# Altium schematic sheet OBC.SchDoc(OBC)
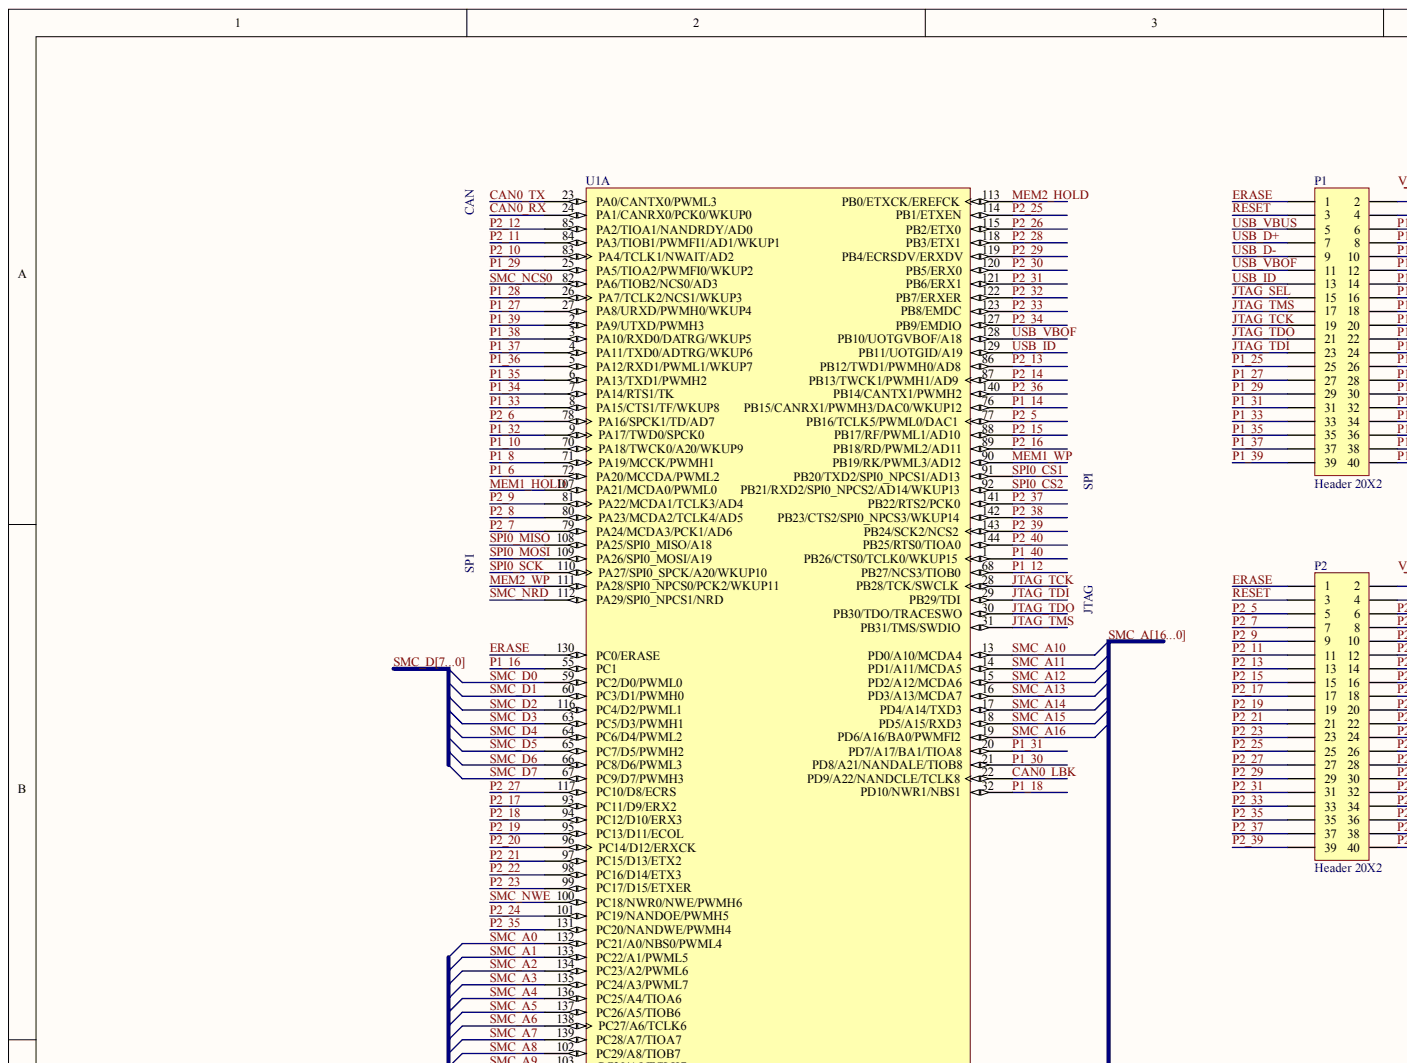
[diagram p0: OBC.SchDoc, top left]
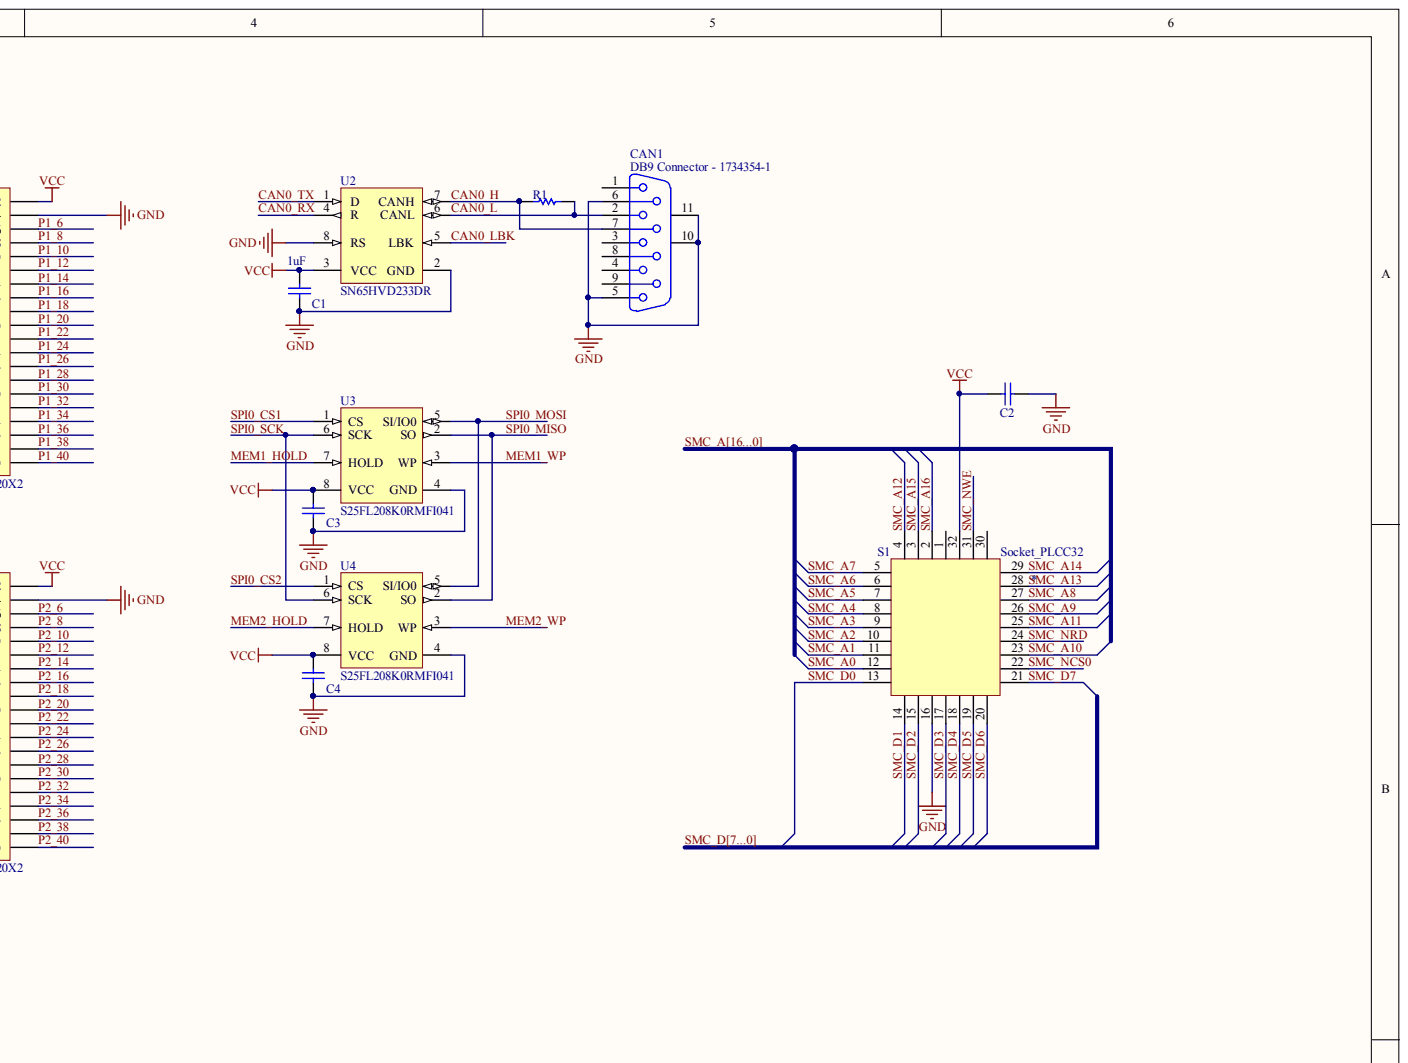
[diagram p1: OBC.SchDoc, top right]
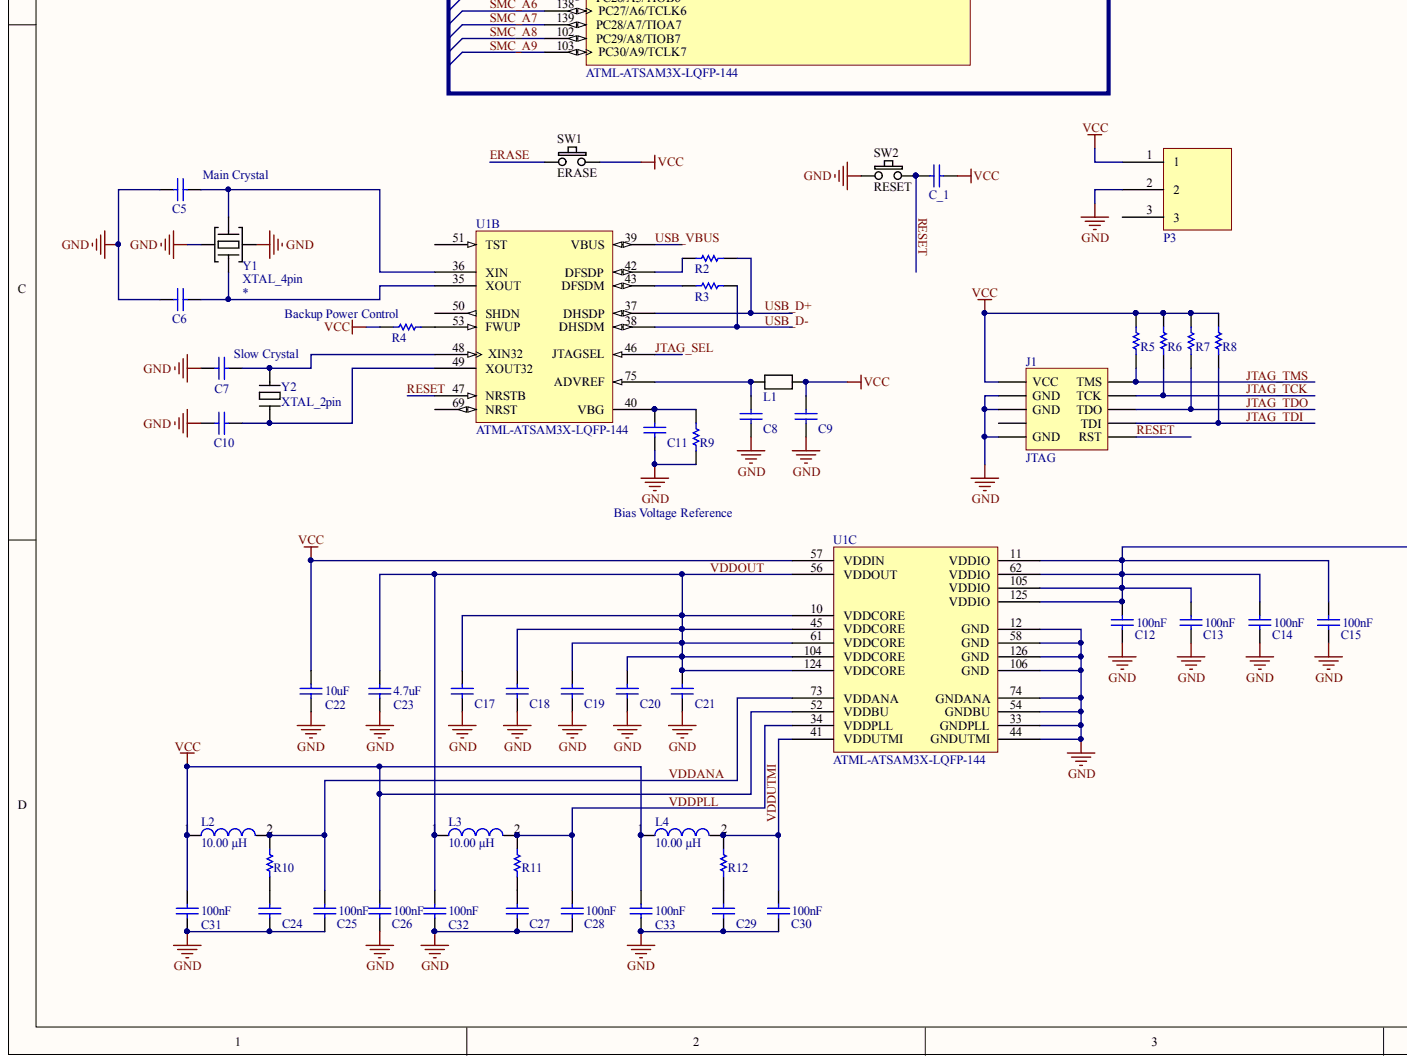
[diagram p2: OBC.SchDoc, bottom left]
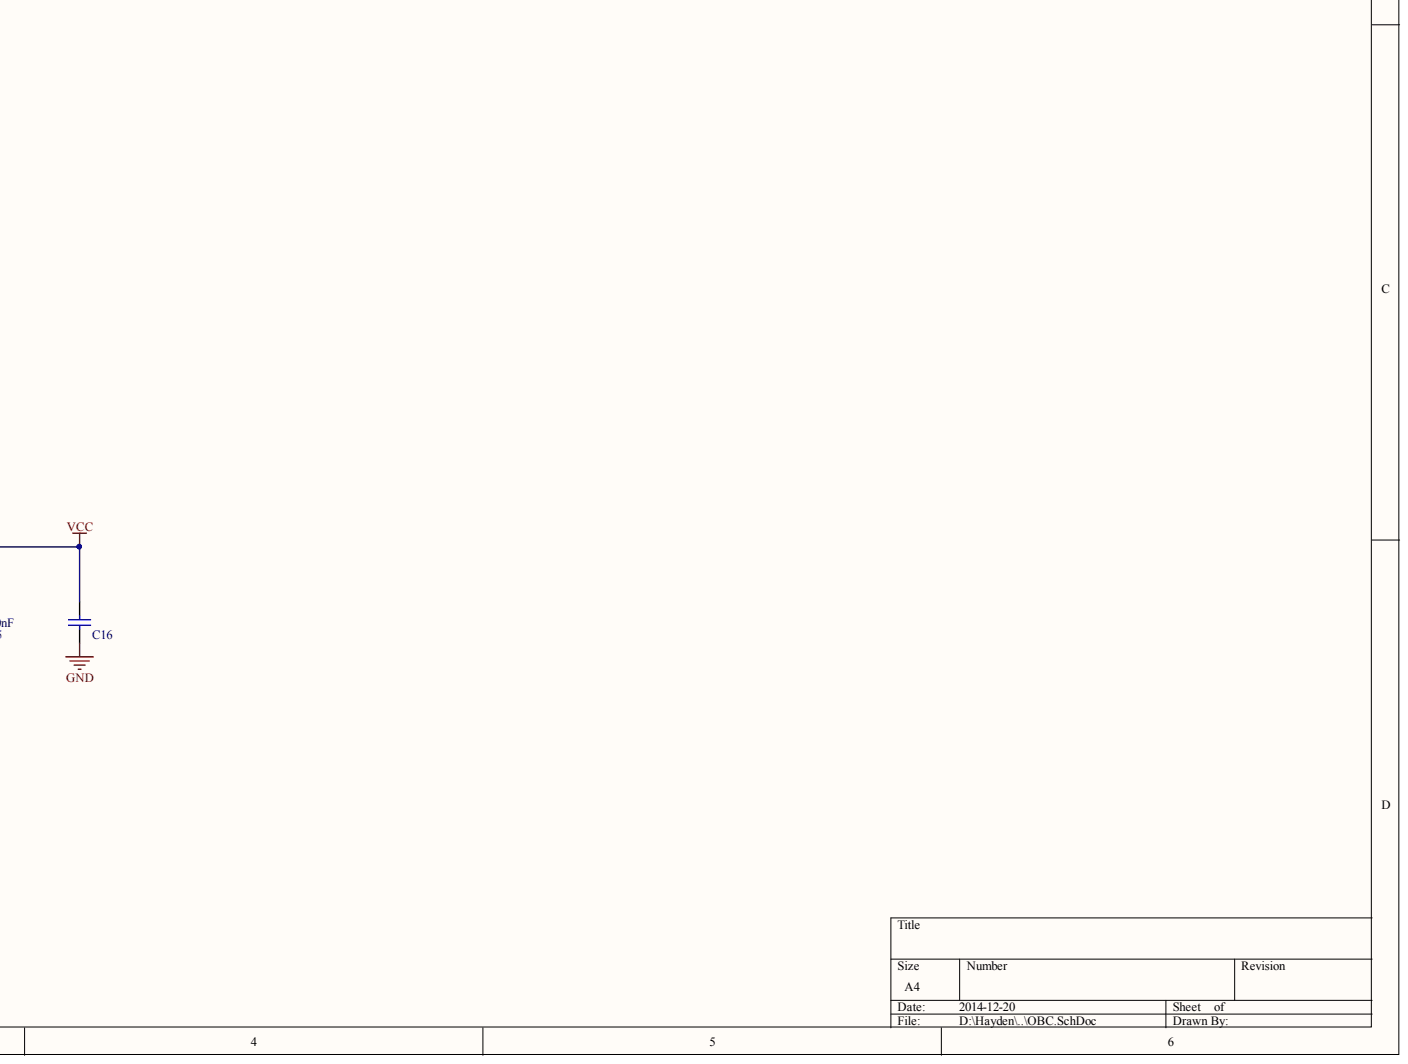
[diagram p3: OBC.SchDoc, bottom right]

## Parts
C1  value 1uF  [CAPC0805(2012)100_M]  (hidden comment: CAP 1uF 10V 0805(2012))
C2  CAP 22nF 10V 0805(2012)  [CAPC0805(2012)100_M]  (value not drawn)
C3  CAP 22nF 10V 0805(2012)  [CAPC0805(2012)100_M]  (value not drawn)
C4  CAP 22nF 10V 0805(2012)  [CAPC0805(2012)100_M]  (value not drawn)
C5  CAP 20pF 10V 0805(2012)  [CAPC0805(2012)100_N]  (value not drawn)
C6  CAP 20pF 10V 0805(2012)  [CAPC0805(2012)100_N]  (value not drawn)
C7  CAP 20pF 10V 0805(2012)  [CAPC0805(2012)100_N]  (value not drawn)
C8  CAP 100pF 10V 0805(2012)  [CAPC0805(2012)100_M]  (value not drawn)
C9  CAP 100pF 10V 0805(2012)  [CAPC0805(2012)100_M]  (value not drawn)
C10  CAP 20pF 10V 0805(2012)  [CAPC0805(2012)100_N]  (value not drawn)
C11  CAP 10pF 10V 0805(2012)  [CAPC0805(2012)100_L]  (value not drawn)
C12  value 100nF  [CAPC0805(2012)100_N]  (hidden comment: CAP 100nF 10V 0805(2012))
C13  value 100nF  [CAPC0805(2012)100_N]  (hidden comment: CAP 100nF 10V 0805(2012))
C14  value 100nF  [CAPC0805(2012)100_N]  (hidden comment: CAP 100nF 10V 0805(2012))
C15  value 100nF  [CAPC0805(2012)100_N]  (hidden comment: CAP 100nF 10V 0805(2012))
C16  CAP 4.7uF 10V 0805(2012)  [CAPC0805(2012)145_N]  (value not drawn)
C17  CAP 100nF 10V 0805(2012)  [CAPC0805(2012)100_N]  (value not drawn)
C18  CAP 100nF 10V 0805(2012)  [CAPC0805(2012)100_N]  (value not drawn)
C19  CAP 100nF 10V 0805(2012)  [CAPC0805(2012)100_N]  (value not drawn)
C20  CAP 100nF 10V 0805(2012)  [CAPC0805(2012)100_N]  (value not drawn)
C21  CAP 100nF 10V 0805(2012)  [CAPC0805(2012)100_N]  (value not drawn)
C22  value 10uF  [CAPC0805(2012)145_N]  (hidden comment: CAP 10uF 10V 0805(2012))
C23  value 4.7uF  [CAPC0805(2012)145_N]  (hidden comment: CAP 4.7uF 10V 0805(2012))
C24  CAP 4.7uF 10V 0805(2012)  [CAPC0805(2012)145_N]  (value not drawn)
C25  value 100nF  [CAPC0805(2012)100_N]  (hidden comment: CAP 100nF 10V 0805(2012))
C26  value 100nF  [CAPC0805(2012)100_N]  (hidden comment: CAP 100nF 10V 0805(2012))
C27  CAP 4.7uF 10V 0805(2012)  [CAPC0805(2012)145_N]  (value not drawn)
C28  value 100nF  [CAPC0805(2012)100_N]  (hidden comment: CAP 100nF 10V 0805(2012))
C29  CAP 4.7uF 10V 0805(2012)  [CAPC0805(2012)145_N]  (value not drawn)
C30  value 100nF  [CAPC0805(2012)100_N]  (hidden comment: CAP 100nF 10V 0805(2012))
C31  value 100nF  [CAPC0805(2012)100_N]  (hidden comment: CAP 100nF 10V 0805(2012))
C32  value 100nF  [CAPC0805(2012)100_N]  (hidden comment: CAP 100nF 10V 0805(2012))
C33  value 100nF  [CAPC0805(2012)100_N]  (hidden comment: CAP 100nF 10V 0805(2012))
C_1  CAP 10nF 10V 0805(2012)  [CAPC0805(2012)100_L]  (value not drawn)
CAN1  DB9 Connector - 1734354-1  [DB9 Connector - 1734354-1]
J1  JTAG  [Header_2X5_0.5]
L1  MH2029-300Y  [0805]  (value not drawn)
L2  Inductance: 10.00 µH  [SMD-0805]  (hidden comment: 7447908)
L3  Inductance: 10.00 µH  [SMD-0805]  (hidden comment: 7447908)
L4  Inductance: 10.00 µH  [SMD-0805]  (hidden comment: 7447908)
P1  Header 20X2  [HDR2X20]
P2  Header 20X2  [HDR2X20]
P3  3V3 In  [Barrel_5.5x9]  (value not drawn)
R1  120R 5% 0805(2012)  [RESC0805(2012)_N]  (value not drawn)
R2  39R 1% 0805(2012)  [RESC0805(2012)_N]  (value not drawn)
R3  39R 1% 0805(2012)  [RESC0805(2012)_N]  (value not drawn)
R4  100K 5% 0805(2012)  [RESC0805(2012)_L]  (value not drawn)
R5  100K 5% 0805(2012)  [RESC0805(2012)_L]  (value not drawn)
R6  100K 5% 0805(2012)  [RESC0805(2012)_L]  (value not drawn)
R7  100K 5% 0805(2012)  [RESC0805(2012)_L]  (value not drawn)
R8  100K 5% 0805(2012)  [RESC0805(2012)_L]  (value not drawn)
R9  6K8 1% 0805(2012)  [RESC0805(2012)_N]  (value not drawn)
R10  1R 5% 0805(2012)  [RESC0805(2012)_L]  (value not drawn)
R11  1R 5% 0805(2012)  [RESC0805(2012)_L]  (value not drawn)
R12  1R 5% 0805(2012)  [RESC0805(2012)_L]  (value not drawn)
S1  Socket_PLCC32  [Socket_PLCC32]
SW1  ERASE  [SW_TAC_6x6]
SW2  RESET  [SW_TAC_6x6]
U1  ATML-ATSAM3X-LQFP-144  [LQFP144_L]  (parts A,B,C)
U2  SN65HVD233DR  [PCA82C250, excludes pin5]
U3  S25FL208K0RMFI041  [SOIC8]
U4  S25FL208K0RMFI041  [SOIC8]
Y1  XTAL_4pin  [XTAL_3.2x2.5_SMD]
Y2  XTAL_2pin  [XTAL_3.2x1.5_SMD]

## Nets
GND: C1.1 C2.1 C3.1 C4.1 C5.1 C6.1 C7.1 C8.1 C9.1 C10.1 C11.2 C12.2 C13.2 C14.2 C15.2 C16.1 C17.2 C18.2 C19.2 C20.2 C21.2 C22.1 C23.2 C24.1 C25.2 C26.2 C27.1 C28.2 C29.1 C30.2 C31.2 C32.2 C33.2 CAN1.5 CAN1.6 CAN1.10 CAN1.11 J1.3(GND) J1.5(GND) J1.9(GND) P1.4 P2.4 P3.2 R9.2 S1.16 SW2.1 U1.12(GND) U1.33(GNDPLL) U1.44(GNDUTMI) U1.54(GNDBU) U1.58(GND) U1.74(GNDANA) U1.106(GND) U1.126(GND) U2.2(GND) U2.8(RS) U3.4(GND) U4.4(GND) Y1.2 Y1.4
VCC: C1.2 C2.2 C3.2 C4.2 C9.2 C12.1 C13.1 C14.1 C15.1 C16.2 C22.2 C26.1 C31.1 C33.1 C_1.2 J1.1(VCC) L1.2 L2.1 L4.1 P1.2 P2.2 P3.1 R4.1 R5.2 R6.2 R7.2 R8.2 S1.32 SW1.2 U1.11(VDDIO) U1.52(VDDBU) U1.57(VDDIN) U1.62(VDDIO) U1.105(VDDIO) U1.125(VDDIO) U2.3(VCC) U3.8(VCC) U4.8(VCC)
CAN0_H: CAN1.7 R1.1 U2.7(CANH)
CAN0_L: CAN1.2 R1.2 U2.6(CANL)
CAN0_LBK: U1.22(PD9/A22/NANDCLE/TCLK8) U2.5(LBK)
CAN0_RX: U1.24(PA1/CANRX0/PCK0/WKUP0) U2.4(R)
CAN0_TX: U1.23(PA0/CANTX0/PWML3) U2.1(D)
ERASE: P1.1 P2.1 SW1.1 U1.130(PC0/ERASE)
JTAG_SEL: P1.15 U1.46(JTAGSEL)
JTAG_TCK: J1.4(TCK) P1.19 R6.1 U1.28(PB28/TCK/SWCLK)
JTAG_TDI: J1.8(TDI) P1.23 R8.1 U1.29(PB29/TDI)
JTAG_TDO: J1.6(TDO) P1.21 R7.1 U1.30(PB30/TDO/TRACESWO)
JTAG_TMS: J1.2(TMS) P1.17 R5.1 U1.31(PB31/TMS/SWDIO)
MEM1_HOLD: U1.107(PA21/MCDA0/PWML0) U3.7(HOLD)
MEM1_WP: U1.90(PB19/RK/PWML3/AD12) U3.3(WP)
MEM2_HOLD: U1.113(PB0/ETXCK/EREFCK) U4.7(HOLD)
MEM2_WP: U1.111(PA28/SPI0_NPCS0/PCK2/WKUP11) U4.3(WP)
P1_6: P1.6 U1.72(PA20/MCCDA/PWML2)
P1_8: P1.8 U1.71(PA19/MCCK/PWMH1)
P1_10: P1.10 U1.70(PA18/TWCK0/A20/WKUP9)
P1_12: P1.12 U1.68(PB27/NCS3/TIOB0)
P1_14: P1.14 U1.76(PB15/CANRX1/PWMH3/DAC0/WKUP12)
P1_16: P1.16 U1.55(PC1)
P1_18: P1.18 U1.32(PD10/NWR1/NBS1)
P1_20: P1.20
P1_22: P1.22
P1_24: P1.24
P1_25: P1.25
P1_26: P1.26
P1_27: P1.27 U1.27(PA8/URXD/PWMH0/WKUP4)
P1_28: P1.28 U1.26(PA7/TCLK2/NCS1/WKUP3)
P1_29: P1.29 U1.25(PA5/TIOA2/PWMFI0/WKUP2)
P1_30: P1.30 U1.21(PD8/A21/NANDALE/TIOB8)
P1_31: P1.31 U1.20(PD7/A17/BA1/TIOA8)
P1_32: P1.32 U1.9(PA17/TWD0/SPCK0)
P1_33: P1.33 U1.8(PA15/CTS1/TF/WKUP8)
P1_34: P1.34 U1.7(PA14/RTS1/TK)
P1_35: P1.35 U1.6(PA13/TXD1/PWMH2)
P1_36: P1.36 U1.5(PA12/RXD1/PWML1/WKUP7)
P1_37: P1.37 U1.4(PA11/TXD0/ADTRG/WKUP6)
P1_38: P1.38 U1.3(PA10/RXD0/DATRG/WKUP5)
P1_39: P1.39 U1.2(PA9/UTXD/PWMH3)
P1_40: P1.40 U1.1(PB26/CTS0/TCLK0/WKUP15)
P2_5: P2.5 U1.77(PB16/TCLK5/PWML0/DAC1)
P2_6: P2.6 U1.78(PA16/SPCK1/TD/AD7)
P2_7: P2.7 U1.79(PA24/MCDA3/PCK1/AD6)
P2_8: P2.8 U1.80(PA23/MCDA2/TCLK4/AD5)
P2_9: P2.9 U1.81(PA22/MCDA1/TCLK3/AD4)
P2_10: P2.10 U1.83(PA4/TCLK1/NWAIT/AD2)
P2_11: P2.11 U1.84(PA3/TIOB1/PWMFI1/AD1/WKUP1)
P2_12: P2.12 U1.85(PA2/TIOA1/NANDRDY/AD0)
P2_13: P2.13 U1.86(PB12/TWD1/PWMH0/AD8)
P2_14: P2.14 U1.87(PB13/TWCK1/PWMH1/AD9)
P2_15: P2.15 U1.88(PB17/RF/PWML1/AD10)
P2_16: P2.16 U1.89(PB18/RD/PWML2/AD11)
P2_17: P2.17 U1.93(PC11/D9/ERX2)
P2_18: P2.18 U1.94(PC12/D10/ERX3)
P2_19: P2.19 U1.95(PC13/D11/ECOL)
P2_20: P2.20 U1.96(PC14/D12/ERXCK)
P2_21: P2.21 U1.97(PC15/D13/ETX2)
P2_22: P2.22 U1.98(PC16/D14/ETX3)
P2_23: P2.23 U1.99(PC17/D15/ETXER)
P2_24: P2.24 U1.101(PC19/NANDOE/PWMH5)
P2_25: P2.25 U1.114(PB1/ETXEN)
P2_26: P2.26 U1.115(PB2/ETX0)
P2_27: P2.27 U1.117(PC10/D8/ECRS)
P2_28: P2.28 U1.118(PB3/ETX1)
P2_29: P2.29 U1.119(PB4/ECRSDV/ERXDV)
P2_30: P2.30 U1.120(PB5/ERX0)
P2_31: P2.31 U1.121(PB6/ERX1)
P2_32: P2.32 U1.122(PB7/ERXER)
P2_33: P2.33 U1.123(PB8/EMDC)
P2_34: P2.34 U1.127(PB9/EMDIO)
P2_35: P2.35 U1.131(PC20/NANDWE/PWMH4)
P2_36: P2.36 U1.140(PB14/CANTX1/PWMH2)
P2_37: P2.37 U1.141(PB22/RTS2/PCK0)
P2_38: P2.38 U1.142(PB23/CTS2/SPI0_NPCS3/WKUP14)
P2_39: P2.39 U1.143(PB24/SCK2/NCS2)
P2_40: P2.40 U1.144(PB25/RTS0/TIOA0)
RESET: C_1.1 J1.10(RST) P1.3 P2.3 SW2.2 U1.47(NRSTB)
SMC_A0: S1.12 U1.132(PC21/A0/NBS0/PWML4)
SMC_A1: S1.11 U1.133(PC22/A1/PWML5)
SMC_A2: S1.10 U1.134(PC23/A2/PWML6)
SMC_A3: S1.9 U1.135(PC24/A3/PWML7)
SMC_A4: S1.8 U1.136(PC25/A4/TIOA6)
SMC_A5: S1.7 U1.137(PC26/A5/TIOB6)
SMC_A6: S1.6 U1.138(PC27/A6/TCLK6)
SMC_A7: S1.5 U1.139(PC28/A7/TIOA7)
SMC_A8: S1.27 U1.102(PC29/A8/TIOB7)
SMC_A9: S1.26 U1.103(PC30/A9/TCLK7)
SMC_A10: S1.23 U1.13(PD0/A10/MCDA4)
SMC_A11: S1.25 U1.14(PD1/A11/MCDA5)
SMC_A12: S1.4 U1.15(PD2/A12/MCDA6)
SMC_A13: S1.28 U1.16(PD3/A13/MCDA7)
SMC_A14: S1.29 U1.17(PD4/A14/TXD3)
SMC_A15: S1.3 U1.18(PD5/A15/RXD3)
SMC_A16: S1.2 U1.19(PD6/A16/BA0/PWMFI2)
SMC_D0: S1.13 U1.59(PC2/D0/PWML0)
SMC_D1: S1.14 U1.60(PC3/D1/PWMH0)
SMC_D2: S1.15 U1.116(PC4/D2/PWML1)
SMC_D3: S1.17 U1.63(PC5/D3/PWMH1)
SMC_D4: S1.18 U1.64(PC6/D4/PWML2)
SMC_D5: S1.19 U1.65(PC7/D5/PWMH2)
SMC_D6: S1.20 U1.66(PC8/D6/PWML3)
SMC_D7: S1.21 U1.67(PC9/D7/PWMH3)
SMC_NCS0: S1.22 U1.82(PA6/TIOB2/NCS0/AD3)
SMC_NRD: S1.24 U1.112(PA29/SPI0_NPCS1/NRD)
SMC_NWE: S1.31 U1.100(PC18/NWR0/NWE/PWMH6)
SPI0_CS1: U1.91(PB20/TXD2/SPI0_NPCS1/AD13) U3.1(CS)
SPI0_CS2: U1.92(PB21/RXD2/SPI0_NPCS2/AD14/WKUP13) U4.1(CS)
SPI0_MISO: U1.108(PA25/SPI0_MISO/A18) U3.2(SO) U4.2(SO)
SPI0_MOSI: U1.109(PA26/SPI0_MOSI/A19) U3.5(SI/IO0) U4.5(SI/IO0)
SPI0_SCK: U1.110(PA27/SPI0_SPCK/A20/WKUP10) U3.6(SCK) U4.6(SCK)
USB_D+: P1.7 R2.2 U1.37(DHSDP)
USB_D-: P1.9 R3.2 U1.38(DHSDM)
USB_ID: P1.13 U1.129(PB11/UOTGID/A19)
USB_VBOF: P1.11 U1.128(PB10/UOTGVBOF/A18)
USB_VBUS: P1.5 U1.39(VBUS)
VDDANA: C25.1 L2.2 R10.1 U1.73(VDDANA)
VDDOUT: C17.1 C18.1 C19.1 C20.1 C21.1 C23.1 C32.1 L3.1 U1.10(VDDCORE) U1.45(VDDCORE) U1.56(VDDOUT) U1.61(VDDCORE) U1.104(VDDCORE) U1.124(VDDCORE)
VDDPLL: C28.1 L3.2 R11.1 U1.34(VDDPLL)
VDDUTMI: C30.1 L4.2 R12.1 U1.41(VDDUTMI)
NetC5_2: C5.2 U1.36(XIN) Y1.1
NetC6_2: C6.2 U1.35(XOUT) Y1.3
NetC7_2: C7.2 U1.48(XIN32) Y2.1
NetC8_2: C8.2 L1.1 U1.75(ADVREF)
NetC10_2: C10.2 U1.49(XOUT32) Y2.2
NetC11_1: C11.1 R9.1 U1.40(VBG)
NetC24_2: C24.2 R10.2
NetC27_2: C27.2 R11.2
NetC29_2: C29.2 R12.2
NetR2_1: R2.1 U1.42(DFSDP)
NetR3_1: R3.1 U1.43(DFSDM)
NetR4_2: R4.2 U1.53(FWUP)
SMC_A[16..-1]:
SMC_D[7..-1]:

Not connected: CAN1 1 3 4 8 9; J1 7; P3 3; S1 1 30; U1 50(SHDN) 51(TST) 69(NRST)

## Library data of the parts
C1 = CMP-1036-04741-1: CAP 1uF 10V ±20% 0805 (2012 Metric) Thickness 1mm SMD; Case-EIA: 0805; Case-Metric: 2012; Component Kind: Standard; Library Name: Altium Content Vault; Max Thickness: 1 mm; Rated Voltage: 10 V; Technology: SMT; Tolerance: ±20%
C2-C4 = CMP-1036-04036-1: CAP 22nF 10V ±20% 0805 (2012 Metric) Thickness 1mm SMD; Case-EIA: 0805; Case-Metric: 2012; Component Kind: Standard; Library Name: Altium Content Vault; Max Thickness: 1 mm; Rated Voltage: 10 V; Technology: SMT; Tolerance: ±20%; Value: 22nF
C5-C7 C10 = CMP-1036-01177-1: CAP 20pF 10V ±5% 0805 (2012 Metric) Thickness 1mm SMD; Case-EIA: 0805; Case-Metric: 2012; Component Kind: Standard; Library Name: Altium Content Vault; Max Thickness: 1 mm; Rated Voltage: 10 V; Technology: SMT; Tolerance: ±5%; Value: 20pF
C8 C9 = CMP-1036-01932-1: CAP 100pF 10V ±5% 0805 (2012 Metric) Thickness 1mm SMD; Case-EIA: 0805; Case-Metric: 2012; Component Kind: Standard; Library Name: Altium Content Vault; Max Thickness: 1 mm; Rated Voltage: 10 V; Technology: SMT; Tolerance: ±5%; Value: 100pF
C11 = CMP-1036-00826-1: CAP 10pF 10V ±5% 0805 (2012 Metric) Thickness 1mm SMD; Case-EIA: 0805; Case-Metric: 2012; Component Kind: Standard; Library Name: Altium Content Vault; Max Thickness: 1 mm; Rated Voltage: 10 V; Technology: SMT; Tolerance: ±5%; Value: 10pF
C12-C15 C17-C21 C25 C26 C28 C30-C33 = CMP-1036-04396-1: CAP 100nF 10V ±20% 0805 (2012 Metric) Thickness 1mm SMD; Case-EIA: 0805; Case-Metric: 2012; Component Kind: Standard; Library Name: Altium Content Vault; Max Thickness: 1 mm; Rated Voltage: 10 V; Technology: SMT; Tolerance: ±20%
  C17-C21: Value: 100nF
C16 C23 C24 C27 C29 = CMP-1036-04884-1: CAP 4.7uF 10V ±20% 0805 (2012 Metric) Thickness 1.45mm SMD; Case-EIA: 0805; Case-Metric: 2012; Component Kind: Standard; Library Name: Altium Content Vault; Max Thickness: 1.45 mm; Rated Voltage: 10 V; Technology: SMT; Tolerance: ±20%
  C16 C24 C27 C29: Value: 4.7uF
C22 = CMP-1036-04924-1: CAP 10uF 10V ±20% 0805 (2012 Metric) Thickness 1.45mm SMD; Case-EIA: 0805; Case-Metric: 2012; Component Kind: Standard; Library Name: Altium Content Vault; Max Thickness: 1.45 mm; Rated Voltage: 10 V; Technology: SMT; Tolerance: ±20%
C_1 = CMP-1036-03811-1: CAP 10nF 10V ±20% 0805 (2012 Metric) Thickness 1mm SMD; Case-EIA: 0805; Case-Metric: 2012; Component Kind: Standard; Library Name: Altium Content Vault; Max Thickness: 1 mm; Rated Voltage: 10 V; Technology: SMT; Tolerance: ±20%; Value: 10nF
CAN1 = DB9 Connector - 1734354-1: CONN D-SUB RCPT R/A 9POS GOLD/FL; Component Kind: Standard; Library Name: SS_Unified_Library.IntLib
J1 = Header_JTAG: ARM JTAG Header (0.05" pitch); Component Kind: Standard; Library Name: SS_Unified_Library.IntLib
L1 = MH2029-300Y: Ferrite Choke; Component Kind: Standard; Library Name: SS_Unified_Library.IntLib
L2-L4 = CMP-0221-00058-1: SMD-Multilayer Inductor WE-MI, L = 10.00 µH; Component Kind: Standard; ComponentLink1Description: Manufacturer Link; ComponentLink1URL: http://www.we-online.com; ComponentLink2Description: Datasheet; ComponentLink2URL: http://www.we-online.de/katalog/media/pdf/7447908.pdf; DatasheetVersion: ver 4, Oct-2004; Library Name: Altium Content Vault; PackageDescription: Chip Inductor, 2-Leads, Body 2.0 x 1.2 mm; PackageReference: SMD-0805; PackageVersion: 2005
P1 P2 = Header 20X2: Header, 20-Pin, Dual row; Component Kind: Standard; LatestRevisionDate: 17-Jul-2002; LatestRevisionNote: Re-released for DXP Platform.; Signal Integrity: Connector; Library Name: SS_Unified_Library.IntLib; Publisher: Altium Limited
P3 = Power Jack: Component Kind: Standard; Library Name: SS_Unified_Library.IntLib
R1 = CMP-1013-00052-1: 120R 0.125W 5% 0805 (2012 Metric)  SMD; Case-EIA: 0805; Case-Metric: 2012; Value: 120.00 Ohm; Component Kind: Standard; Library Name: Altium Content Vault; Power: 0.125 W; Technology: SMT; Tolerance: 5 %
R2 R3 = CMP-1013-00350-1: 39R 0.125W 1% 0805 (2012 Metric)  SMD; Case-EIA: 0805; Case-Metric: 2012; Value: 39.00 Ohm; Component Kind: Standard; Library Name: Altium Content Vault; Power: 0.125 W; Technology: SMT; Tolerance: 1 %
R4-R8 = CMP-1013-00122-1: 100K 0.125W 5% 0805 (2012 Metric)  SMD; Case-EIA: 0805; Case-Metric: 2012; Value: 100000.00 Ohm; Component Kind: Standard; Library Name: Altium Content Vault; Power: 0.125 W; Technology: SMT; Tolerance: 5 %
R9 = CMP-1013-00604-1: 6K8 0.125W 1% 0805 (2012 Metric)  SMD; Case-EIA: 0805; Case-Metric: 2012; Value: 6800.00 Ohm; Component Kind: Standard; Library Name: Altium Content Vault; Power: 0.125 W; Technology: SMT; Tolerance: 1 %
R10-R12 = CMP-1013-00002-1: 1R 0.125W 5% 0805 (2012 Metric)  SMD; Case-EIA: 0805; Case-Metric: 2012; Value: 1.00 Ohm; Component Kind: Standard; Library Name: Altium Content Vault; Power: 0.125 W; Technology: SMT; Tolerance: 5 %
SW1 SW2 = SW-PB: SW-D6-R / SW-DS-S / SW-14X14 / SW-7X7; Component Kind: Standard; Library Name: SS_Unified_Library.IntLib
U2 = CMP-0315-00038-1: 3.3V CAN Transceiver with Standby Mode, Loop-back, 6 mA, -40 to 125 degC, 8-pin SOIC (D), Green (RoHS & no Sb/Br); Manufacturer: Texas Instruments; Bus Fault Voltage(V): +/-30; Drivers Per Package: 1; ICC(Max)(mA): 6; Rating: Catalog; Supply Voltage(s)(V): 3.3; Transient Pulse Protection(V): -100 to 100; Code_JEDEC: MS-012AA; Component Kind: Standard; ComponentLink1Description: Datasheet; ComponentLink1URL: http://www.ti.com/general/docs/lit/getliterature.tsp?genericPartNumber=SN65HVD233&fileType=pdf; DatasheetVersion: SLLS557F; Eco-Plan: Green (RoHS & no Sb/Br); Features: Loop Back; Isolated: No; Library Name: Altium Content Vault; Low Power Mode: Standby; Mounting Technology: Surface Mount; PackageDescription: 8-Pin Plastic Small Outline Integrated Circuit, 4.9 x 3.9 mm, Pitch 1.27 mm Pitch; PackageReference: D8; PackageVersion: revM, Jun-2011; PartNumber: SN65HVD233DR; Receivers Per Package: 1; SubFamily: CAN
U3 U4 = S25FL208K0RMFI041: SPI Flash; Component Kind: Standard; Library Name: SS_Unified_Library.IntLib
Y1 = XTAL_4pin: Crystal 4 pin; Component Kind: Standard; Library Name: SS_Unified_Library.IntLib
Y2 = XTAL_2pin: Crystal 2 pin; Component Kind: Standard; Library Name: SS_Unified_Library.IntLib
S1 = Socket_PLCC32: Component Kind: Standard; Library Name: SS_Unified_Library.IntLib
U1 = ATML-ATSAM3X-LQFP-144: Component Kind: Standard; Library Name: SS_Unified_Library.IntLib
